# Altium schematic sheet ESP12.SchDoc
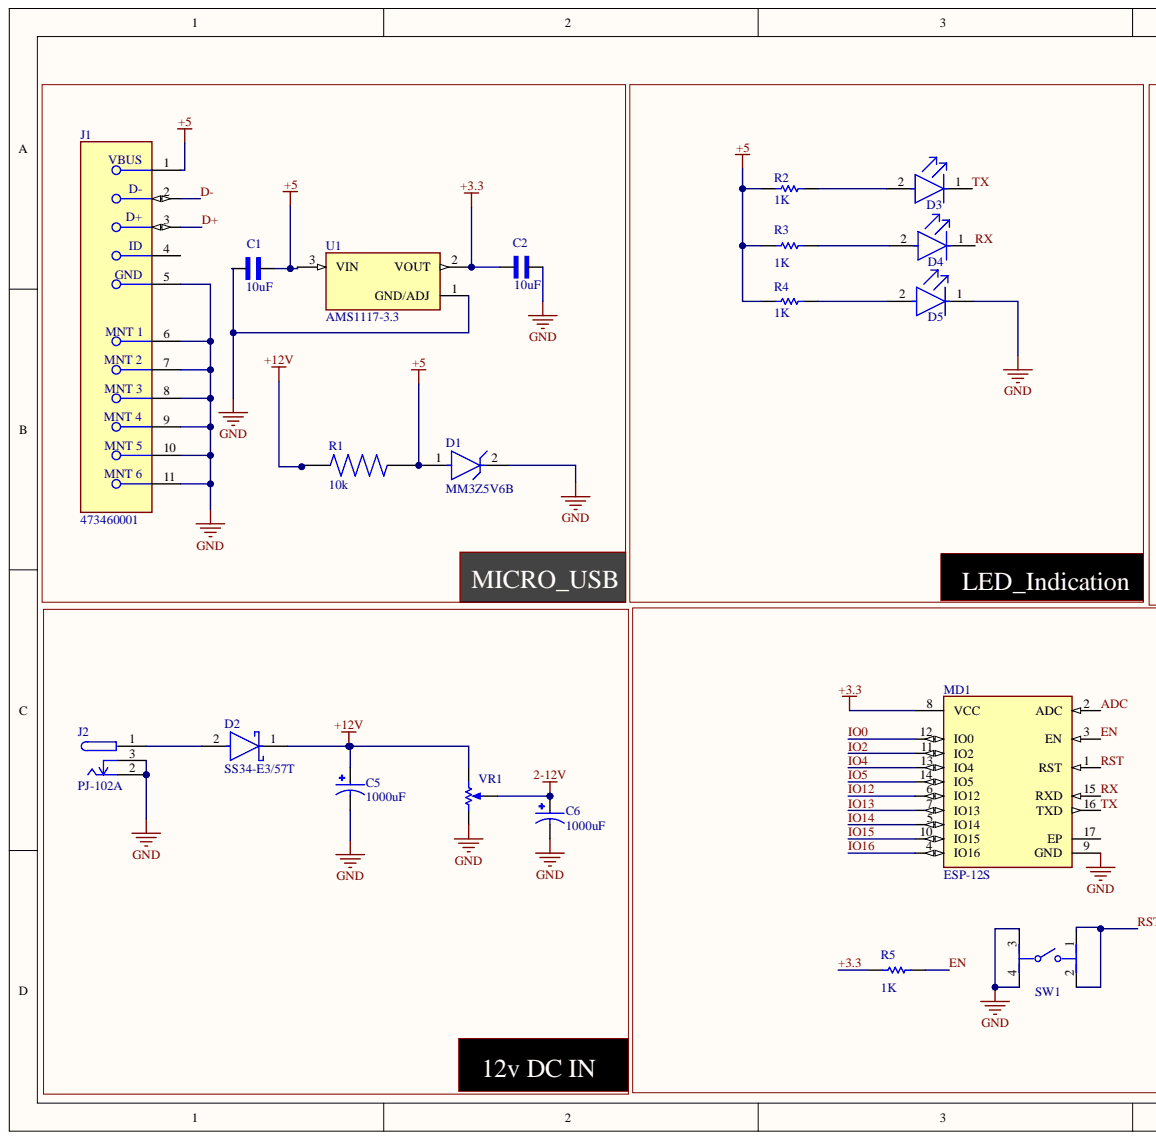
[diagram p0: ESP12.SchDoc, left]
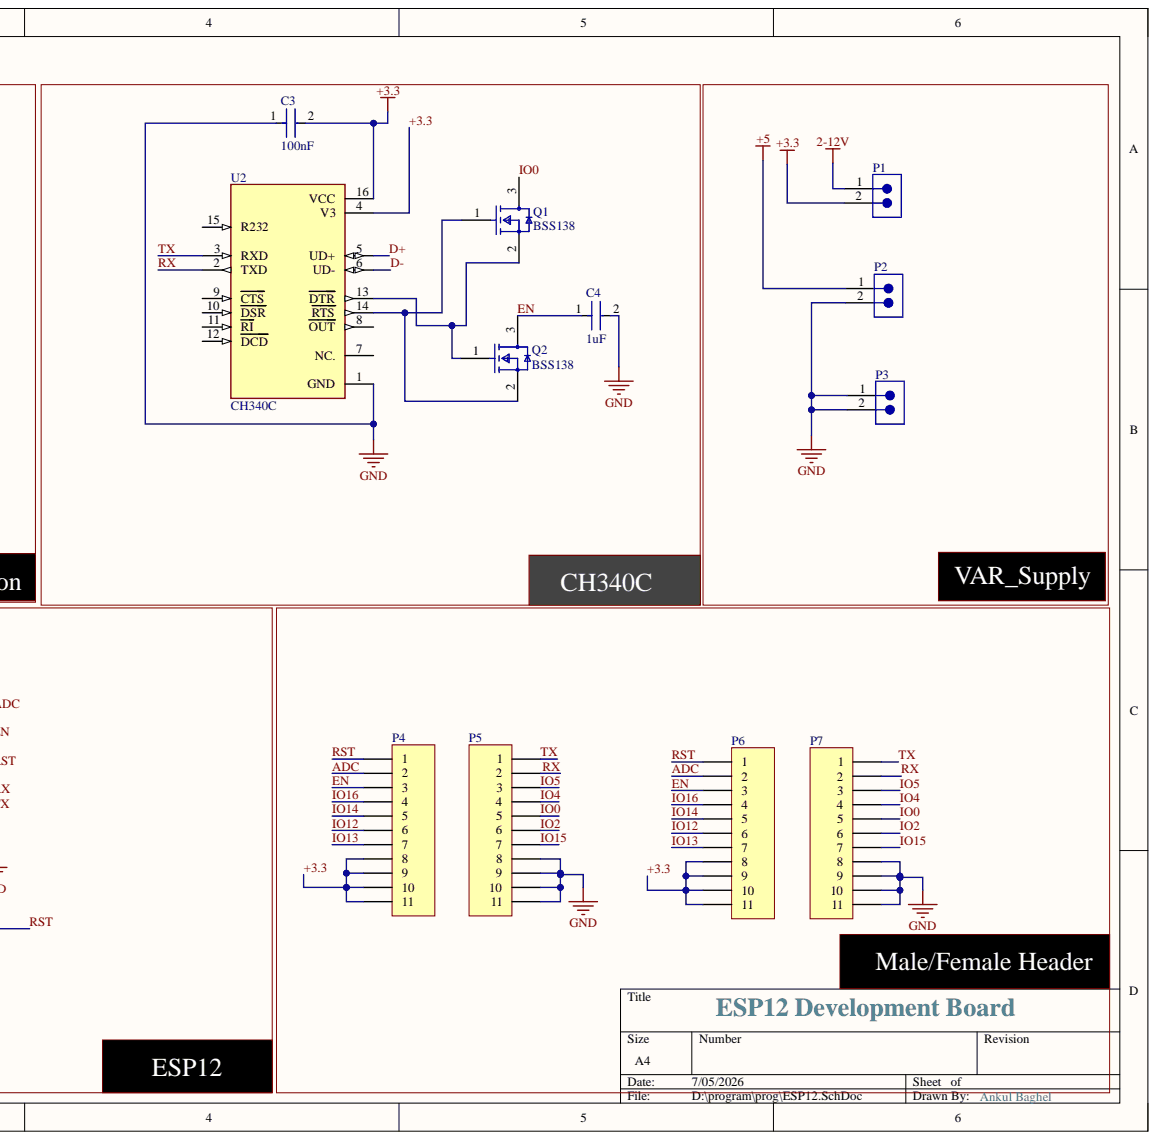
[diagram p1: ESP12.SchDoc, right]

## Parts
C1  10uF  [CAPC2012X145N]
C2  10uF  [CAPC2012X145N]
C3  100nF  [FP-CC0805-0_85-MFG]
C4  1uF  [FP-CC0805-0_85-MFG]
C5  1000uF  [CAPPRD500W60D1000H1600]
C6  1000uF  [CAPPRD500W60D1000H1600]
D1  MM3Z5V6B  [FP-MM3Z5V6B-MFG]
D2  SS34-E3/57T  [FP-95402-MFG]
D3  LTST-C190KRKT  [FP-LTST-C190KRKT-MFG]  (value not drawn)
D4  LTST-C190KGKT  [FP-LTST-C190KGKT-MFG]  (value not drawn)
D5  LTST-C190KRKT  [FP-LTST-C190KRKT-MFG]  (value not drawn)
J1  473460001  [FP-473460001-MFG]
J2  PJ-102A  [FP-PJ-102A-MFG]
MD1  ESP-12S  [FP-ESP-12S-MFG]
P1  61300211121  [61300211121]  (value not drawn)
P2  61300211121  [61300211121]  (value not drawn)
P3  61300211121  [61300211121]  (value not drawn)
P4  Header 11  [HDR1X11]  (value not drawn)
P5  Header 11  [HDR1X11]  (value not drawn)
P6  Header 11  [HDR1X11]  (value not drawn)
P7  Header 11  [HDR1X11]  (value not drawn)
Q1  BSS138  [FP-318-08-IPC_B]
Q2  BSS138  [FP-318-08-IPC_B]
R1  10k  [RESC2012X60N]
R2  1K  [RESC2013X60X35ML10T20]
R3  1K  [RESC2013X60X35ML10T20]
R4  1K  [RESC2013X60X35ML10T20]
R5  1K  [RESC2013X60X35ML10T20]
SW1  PTS645SM43SMTR92LFS  [PTS645SM43SMTR92LFS_MFG]  (value not drawn)
U1  AMS1117-3.3  [SOT229P700X180-4N]
U2  CH340C  [SOIC127P600X180-16N]
VR1  3362P-1-103LF  [BOUR-3362P]  (value not drawn)

## Nets
2-12V: C6.P P1.1 VR1.2
+5: C1.2 D1.1 J1.1 P2.1 R1.2 R2.1 R3.1 R4.1 U1.3
+12V: C5.P D2.1 R1.1 VR1.3
GND: C1.1 C2.2 C3.1 C4.2 C5.N C6.N D1.2 D5.1 J1.5 J1.6 J1.7 J1.8 J1.9 J1.10 J1.11 J2.2 J2.3 MD1.9(GND) P2.2 P3.1 P3.2 P5.8 P5.9 P5.10 P5.11 P7.8 P7.9 P7.10 P7.11 SW1.3 SW1.4 U1.1 U2.1(GND) VR1.1
+3.3: C2.1 C3.2 MD1.8(VCC) P1.2 P4.8 P4.9 P4.10 P4.11 P6.8 P6.9 P6.10 P6.11 R5.1 U1.2 U2.4(V3) U2.16(VCC)
ADC: MD1.2(ADC) P4.2 P6.2
D+: J1.3 U2.5(UD+)
D-: J1.2 U2.6(UD-)
EN: C4.1 MD1.3(EN) P4.3 P6.3 Q2.3 R5.2
IO0: MD1.12(IO0) P5.5 P7.5 Q1.3
IO2: MD1.11(IO2) P5.6 P7.6
IO4: MD1.13(IO4) P5.4 P7.4
IO5: MD1.14(IO5) P5.3 P7.3
IO12: MD1.6(IO12) P4.6 P6.6
IO13: MD1.7(IO13) P4.7 P6.7
IO14: MD1.5(IO14) P4.5 P6.5
IO15: MD1.10(IO15) P5.7 P7.7
IO16: MD1.4(IO16) P4.4 P6.4
RST: MD1.1(RST) P4.1 P6.1 SW1.1 SW1.2
RX: D4.1 MD1.15(RXD) P5.2 P7.2 U2.2(TXD)
TX: D3.1 MD1.16(TXD) P5.1 P7.1 U2.3(RXD)
NetD2_2: D2.2 J2.1
NetD3_2: D3.2 R2.2
NetD4_2: D4.2 R3.2
NetD5_2: D5.2 R4.2
NetQ1_1: Q1.1 Q2.2 U2.14(RTS)
NetQ1_2: Q1.2 Q2.1 U2.13(DTR)

Not connected: J1 4; MD1 17(EP); U2 7(NC.) 8(OUT) 9(CTS) 10(DSR) 11(RI) 12(DCD) 15(R232)

## Library data of the parts
C1 C2 = CC0805KKX5R8BB106: 10 ÂµF Â±10% 25V Ceramic Capacitor X5R 0805 (2012 Metric); MF: Yageo; Check_prices: https://www.snapeda.com/parts/CC0805KKX5R8BB106/Yageo/view-part/?ref=eda; Component Kind: Standard; Library Name: CC0805KKX5R8BB106.IntLib; MP: CC0805KKX5R8BB106; Package: 0805 Yageo; SnapEDA_Link: https://www.snapeda.com/parts/CC0805KKX5R8BB106/Yageo/view-part/?ref=snap
C3 = CMP-2100-03606-3: CAP CER 0.1UF 50V X7R 0805; Manufacturer: Yageo; Manufacturer Part Number: CC0805KRX7R9BB104; Material: Ceramic; Tolerance: 0.1; Automotive: No; Capacitance: 100 nF; Category: Cap; Component Kind: Standard; ComponentLink2Description: Datasheet; ComponentLink2URL: http://www.yageo.com/documents/recent/UPY-GPHC_Y5V_6.3V-to-50V_8.pdf; Device Class L1: Passive Components; Device Class L2: Capacitors; Device Class L3: Ceramic Capacitors; Digikey Description: CAP CER 0.1UF 50V X7R 0805; Footprint Url: http://www.yageo.com/exep/pages/download/literatures/UPY-C_GEN_24.pdf; Height: 0.95mm; Ipc Land Pattern Name: CAPC200125X85; Lead Free: Yes; Library Name: Altium Content Vault; Mouser Description: Multilayer Ceramic Capacitors MLCC - SMD/SMT 100nF 50V X7R 10%; Octopart: https://octopart.com/cc0805krx7r9bb104-yageo-192993; Package: CC0805-0.85; RoHS: TRUE; Temperature: -30°C to +85°C; Temperature Characteristic: X7R; Temperature Range High: +85°C; Temperature Range Low: -30°C; Value: 100 nF; Voltage Rating: 50 V
C4 = CMP-03422-000001-1: CAP CER 1UF 16V X7R 0805; Manufacturer: Yageo; Manufacturer Part Number: CC0805KKX7R7BB105; Material: Ceramic; Tolerance: 0.1; Automotive: No; Capacitance: 1 uF; Category: Cap; Component Kind: Standard; ComponentLink2Description: Datasheet; ComponentLink2URL: http://www.yageo.com/documents/recent/UPY-GPHC_Y5V_6.3V-to-50V_8.pdf; Device Class L1: Passive Components; Device Class L2: Capacitors; Device Class L3: Ceramic Capacitors; Digikey Description: CAP CER 1UF 16V X7R 0805; Footprint Url: http://www.yageo.com/exep/pages/download/literatures/UPY-C_GEN_24.pdf; Height: 0.95mm; Ipc Land Pattern Name: CAPC200125X85; Lead Free: Yes; Library Name: Altium Content Vault; Mouser Description: Multilayer Ceramic Capacitors MLCC - SMD/SMT 1.0uF 16V X7R 10%; Octopart: https://octopart.com/cc0805kkx7r7bb105-yageo-8376555; Package: CC0805-0.85; RoHS: TRUE; Temperature: -30°C to +85°C; Temperature Characteristic: X7R; Temperature Range High: +85°C; Temperature Range Low: -30°C; Value: 1 uF; Voltage Rating: 16 V
C5 C6 = EEUFR1C102B: CAP ALUM 1000UF 20% 16V RADIAL; MF: Panasonic; MP: EEU-FR1C102B; Availability: In Stock; Check_prices: https://www.snapeda.com/parts/EEU-FR1C102B/Panasonic/view-part/2318035/?ref=eda; Component Kind: Standard; Library Name: EEUFR1C102B.IntLib; Package: RADIAL-2 Panasonic; Price: None; Purchase-URL: https://pricing.snapeda.com/search/part/EEU-FR1C102B/?ref=eda; SnapEDA_Link: https://www.snapeda.com/parts/EEU-FR1C102B/Panasonic/view-part/2318035/?ref=snap
D1 = CMP-07164-000010-1: DIODE ZENER 5.6V 200MW SOD323F; Manufacturer: ON Semiconductor; Forward Current: 10mA; Max Junction Temp: +150°C; Max Reverse Leakage Current: 0.9uA; Max Zener Voltage: 5.71V; Reverse Voltage: 2V; Zener Impedance: 37Ω; Automotive: No; Category: Diode; Component Kind: Standard; ComponentLink2Description: Datasheet; ComponentLink2URL: https://www.onsemi.com/pub/Collateral/MM3Z9V1B-D.pdf; Device Class L1: Discrete Semiconductors; Device Class L2: Diodes; Device Class L3: Zener Diodes; Digikey Description: DIODE ZENER 5.6V 200MW SOD323F; Height: 1mm; Lead Free: Yes; Library Name: Altium Content Vault; Manufacturer Part Number: MM3Z5V6B; Mouser Description: Zener Diodes Diode Zener 5.6V 200mW 2%; Nom Zener Voltage: 5.6V; Octopart: https://octopart.com/mm3z5v6b-on+semiconductor-84329898; Package: SOD323F; Power Dissipation: 200mW; RoHS: TRUE; Temperature: to +150°C; Temperature Range High: +150°C
D2 = CMP-02539-000012-1: DIODE SCHOTTKY 40V 3A DO214AB; Manufacturer: Vishay; Max Junction Temp: +150°C; Max Surge Forward Current: 100A; Peak Reverse Current: 0.5mA; Standoff Height: 0mm; Automotive: No; Category: Diode; Component Kind: Standard; ComponentLink2Description: Datasheet; ComponentLink2URL: http://www.vishay.com/docs/88751/ss32.pdf; Device Class L1: Discrete Semiconductors; Device Class L2: Diodes; Device Class L3: Schottky Diodes; Digikey Description: DIODE SCHOTTKY 40V 3A DO214AB; Footprint Url: http://www.vishay.com/docs/95402/smc.pdf; Forward Current: 3A; Height: 2.62mm; Lead Free: Yes; Library Name: Altium Content Vault; Manufacturer Part Number: SS34-E3/57T; Max Repetitive Reverse Voltage: 40V; Mouser Description: Schottky Diodes & Rectifiers 3.0 Amp 40 Volt; Octopart: https://octopart.com/ss34-e3%2F57t-vishay-39552907; Package: 95402; RoHS: TRUE; Temperature: -55°C to +150°C; Temperature Range High: +150°C; Temperature Range Low: -55°C
D3 D5 = CMP-1674-00011-2: LED RED CLEAR CHIP SMD; Dominant Wavelength: 631nm; Forward Current: 30mA; Forward Voltage: 2.4V; Lens Color: Colorless; Lens Style: Diffused; Luminous Intensity: 54mcd; Number of Elements: 1; Power Dissipation: 75mW; Reverse Voltage: 5V; Test Current: 20mA; Viewing Angle: 130°; Wavelength: 639nm; Case Code (Imperial): 0603; Case Code (Metric): 1608; Case/Package: 0603; Color: Red; Component Kind: Standard; Depth: 0.8mm; Height: 0.8mm; Illumination Color: Red; Lead Free: Lead Free; Length: 1.6mm; Lens Transparency: Clear; Library Name: Altium Content Vault; Max Operating Temperature: 85°C; Min Operating Temperature: -55°C; Mount: Surface Mount; Number of LEDs: 1; Number of Pins: 2; Package Quantity: 1; Packaging: Tape and Reel; Power Rating: 75mW; Radiation Hardening: No; Width: 0.8mm
D4 = CMP-2000-05197-2: LED GREEN CLEAR CHIP SMD; Dominant Wavelength: 571nm; Forward Current: 30mA; Forward Voltage: 2V; Lens Color: Colorless; Lens Style: Diffused; Luminous Intensity: 35mcd; Number of Elements: 1; Power Dissipation: 75mW; Reverse Voltage: 5V; Test Current: 20mA; Viewing Angle: 130°; Wavelength: 574nm; Case Code (Imperial): 0603; Case Code (Metric): 1608; Case/Package: 0603; Color: Green; Component Kind: Standard; Depth: 0.8mm; Height: 0.8mm; Illumination Color: Green; Lead Free: Lead Free; Length: 1.6mm; Lens Transparency: Clear; Library Name: Altium Content Vault; Max Operating Temperature: 85°C; Min Operating Temperature: -55°C; Mount: Surface Mount; Number of LEDs: 1; Number of Pins: 2; Package Quantity: 1; Packaging: Tape and Reel; Power Rating: 75mW; Radiation Hardening: No; Width: 0.8mm
J1 = CMP-2000-05246-2: CONN RCPT USB2.0 MICRO B SMD R/A; Manufacturer: Molex; Conn Orientation: Right Angle; Current Rating: 1.8A; Is Female: yes; Number Of Contacts: 5; Pitch: 0.65mm; Shielding: true; Voltage Rating: 30V; Automotive: No; Category: Conn; Component Kind: Standard; ComponentLink2Description: Datasheet; ComponentLink2URL: https://www.molex.com/webdocs/datasheets/pdf/en-us/0473460001_IO_CONNECTORS.pdf; Contact Material: Gold,Nickel; Device Class L1: Connectors; Device Class L2: USB Connectors; Device Class L3: unset; Digikey Description: CONN RCPT USB2.0 MICRO B SMD R/A; Footprint Url: https://www.molex.com/pdm_docs/sd/473460001_sd.pdf; Height: 2.945mm; Lead Free: Yes; Library Name: Altium Content Vault; Manufacturer Part Number: 473460001; Octopart: https://octopart.com/47346-0001-molex-7164928; Package: 0; RoHS: TRUE; Temperature: -30°C to +85°C; Temperature Range High: +85°C; Temperature Range Low: -30°C; Usb Standard: USB 2.0
J2 = CMP-12637-106234071-1: PJ-102A 2.0 x 6.5 mm, 2.5 A, Horizontal, Through Hole, Tapered Pins, Dc Power Jack Connector; Current Rating: 2.5A; Gender: Male; Inside Diameter: 2mm; Insulation Resistance: 50MΩ; Number of Conductors: 2; Number of Contacts: 3; Outside Diameter: 6.5mm; Shielding: No; Voltage Rating: 24V; Color: Black; Component Kind: Standard; Contact Gender: Male; Contact Material: Copper, Nickel, Tin; Contact Plating: Nickel; Diameter: 2.1mm; Flammability Rating: UL94 V-0; Height: 11.2mm; Housing Color: Black; Housing Material: Thermoplastic; Lead Free: Lead Free; Library Name: Altium Content Vault; Lifecycle Status: Production; Max Operating Temperature: 85°C; Min Operating Temperature: -25°C; Mount: Through Hole; NexarPartId: 106234071; Orientation: Horizontal; Packaging: Bulk; RoHS: Compliant; Schedule B: 8536695050, 8536695050; Termination: Through Hole; Voltage Rating (DC): 24V
MD1 = CMP-204316-000002-1: RX TXRX MOD WIFI PCB TRACE SMD; Data Rate: 54Mbps; Frequency: 2.484GHz; Interface: I2C; Sensitivity: -90dBm; Component Kind: Standard; Library Name: Altium Content Vault; Series: -
P1-P3 = CMP-1712-00002-7: THT Vertical Pin Header WR-PHD, Pitch 2.54 mm, Single Row, 2 pins; Connector Type: Male Pin; Contact Resistance: 20mΩ; Current Rating: 3A; Gender: Male; Number of Contacts: 2; Operating Supply Voltage: 250V; Pitch: 2.54mm; Color: Black; Component Kind: Standard; Contact Gender: Male; Contact Material: Gold; Contact Mating Length: 5.9944mm; Contact Plating: Gold; Height: 8.94mm; Housing Material: PBT; Lead Free: Lead Free; Lead Pitch: 2.54mm; Library Name: Altium Content Vault; Max Operating Temperature: 105°C; Min Operating Temperature: -40°C; Mount: Through Hole; Number of Pins: 2; Number of Positions: 2; Number of Rows: 1; Orientation: Vertical; Packaging: Bulk; REACH SVHC: No; RoHS: Compliant; Termination: Through Hole; Voltage Rating: 250V
P4-P7 = Header 11: Header, 11-Pin; Component Kind: Standard; LatestRevisionDate: 17-Jul-2002; LatestRevisionNote: Re-released for DXP Platform.; Library Name: Miscellaneous Connectors.IntLib; Signal Integrity: Connector; Publisher: Altium Limited
Q1 Q2 = CMP-07173-000101-1: MOSFET N-CH 50V 220MA SOT-23; Drain to Source Resistance: 3.5R; Element Configuration: Single; Fall Time: 9ns; Gate to Source Voltage (Vgs): 20V; Input Capacitance: 27pF; Max Power Dissipation: 360mW; Nominal Vgs: 1.3V; Number of Elements: 1; On-State Resistance: 6R; Turn-Off Delay Time: 20ns; Turn-On Delay Time: 2.5ns; Case/Package: SOT-23; Component Kind: Standard; Contact Plating: Tin; Continuous Drain Current (ID): 220mA; Current Rating: 220mA; Drain to Source Breakdown Voltage: 50V; Drain to Source Voltage (Vdss): 50V; Dual Supply Voltage: 50V; Height: 0.93mm; Lead Free: Lead Free; Length: 2.92mm; Library Name: Altium Content Vault; Max Operating Temperature: 150°C; Min Operating Temperature: -55°C; Mount: Surface Mount; Number of Pins: 3; Package Quantity: 1; Packaging: Tape and Reel; Power Dissipation: 360mW; Radiation Hardening: No; Rds On Max: 3.5R; REACH SVHC: No SVHC; Rise Time: 9ns; Termination: SMD/SMT; Threshold Voltage: 1.3V; Voltage Rating (DC): 50V; Weight: 0.03g; Width: 1.3mm
R1 = RC0805JR-0710KL: RESISTOR THICK FILM 5% 0.125W; MF: {'manufacturer': u'YAGEO'}; Availability: Unavailable; Component Kind: Standard; Library Name: RC0805JR-0710KL.IntLib; MP: RC0805JR-0710KL; Package: None; Price: None
R2-R5 = CMP-2100-03671-1: Chip Resistor, 1 KOhm, +/- 1%, 125 mW, -55 to 155 degC, 0805 (2012 Metric), RoHS, Tape and Reel; Manufacturer: Yageo; Max Power Dissipation: 125mW; Resistance: 1KOhm; Temperature Coefficient: 100ppm/degreesC; Voltage Rating: 150V; Case Code (Imperial): 0805; Case Code (Metric): 2012; Case/Package: 0805; Component Kind: Standard; Composition: ThickFilm; Datasheet URL: http://www.yageo.com/NewPortal/yageodocoutput?fileName=/pdf/R-Chip/PYu-RC_Group_51_RoHS_L_5.pdf; Datasheet Version: Rev. 5, 10/2016; Depth: 1.25mm; Height: 0.024inch; Lead Free: LeadFree; Length: 0.079inch; Library Name: Altium Content Vault; Manufacturer URL: http://www.yageo.com/; Max Operating Temperature: 155degC; Military Standard: Not; Min Operating Temperature: -55degC; Number of Pins: 2; Number of Terminations: 2; Package Description: 2-Pin Surface Mounted Device, Body 2 x 1.25 mm; Package Quantity: 1; Package Reference: 0805; Packaging: TapeandReel; Power Rating: 125mW; REACH SVHC: Unknown; RoHSCompliant: true; Termination: SMD/SMT; Tolerance: 1%; Voltage Rating (DC): 150V; Width: 0.049inch
SW1 = CMP-2000-05160-3: Switch Tactile N.O SPST Round Button Gull Wing 0.05A 12VDC 100000Cycle 1.57N SMD T/R; Actuator Length: 850µm; Actuator Material: Nylon, Polyamide; Contact Current Rating: 50mA; Contact Resistance: 100mΩ; Electrical Life: 100kcycles; Illumination Type: Illuminated, Non-Illuminated; Insulation Resistance: 100GΩ; Max Voltage Rating (DC): 12V; Number of Positions: 1; Switch Function: Off-Mom; Case/Package: SMD/SMT; China RoHS: Compliant; Color: Black; Component Kind: Standard; ComponentLink1Description: Manufacturer's Website; ComponentLink1URL: http://www.ck-components.com/; ComponentLink2Description: Datasheet URL; ComponentLink2URL: https://www.ckswitches.com/media/1471/pts645.pdf; Contact Material: Silver; Contact Plating: Silver; Current Rating: 50mA; Depth: 9mm; Height: 3.45mm; HelpURL: https://www.ckswitches.com/media/1471/pts645.pdf; Lead Free: Lead Free; Length: 6mm; Library Name: Altium Content Vault; Life (Cycles): 100kcycles; Lifecycle Status: Production; Manufacturer Package Identifier: PTS645SM43SMTR92LFS; Max Current Rating: 50mA; Max Operating Temperature: 60°C; Mechanical Life: 100kcycles; Min Operating Temperature: -20°C; Mount: Surface Mount; Operating Force: 1.57N; Orientation: Straight; Packaging: Cut Tape (CT); REACH SVHC: Yes; RoHS: Compliant; Termination: Solder; Throw Configuration: SPST; Voltage Rating (DC): 12V
U1 = AMS1117-3.3: Sot223/Pkg 1-Amp 3.3-Volt Low Drop Out Voltage Regulatator; MF: Advanced Monolithic Systems; Check_prices: https://www.snapeda.com/parts/AMS1117-3.3/Advanced+Monolithic+Systems/view-part/?ref=eda; Component Kind: Standard; Library Name: AMS1117-3.3.IntLib; MP: AMS1117-3.3; Package: SOT-223 Seeed Technology; SnapEDA_Link: https://www.snapeda.com/parts/AMS1117-3.3/Advanced+Monolithic+Systems/view-part/?ref=snap
U2 = CH340C: USB to serial chip CH340; MF: WCH; Availability: Not in stock; Check_prices: https://www.snapeda.com/parts/CH340C/WCH/view-part/?ref=eda; Component Kind: Standard; Library Name: CH340C.IntLib; MP: CH340C; Package: None; Price: None; SnapEDA_Link: https://www.snapeda.com/parts/CH340C/WCH/view-part/?ref=snap
VR1 = CMP-1747-00004-1: Square Trimpot(R) Trimming Potentiometer, 10 KOhm, 300 V, -55 to 125 degC, 3-Pin THD, RoHS, Tube; Power Rating: 1 W; Resistance: 50 mohm; Tolerance: 1%; Voltage Rating: 220 mV; Case Code (Imperial): 0612; Case Code (Metric): 1632; Case/Package: 1206; Component Kind: Standard; ComponentLink1Description: Manufacturer URL; ComponentLink1URL: http://www.bourns.com/; ComponentLink2Description: Datasheet; ComponentLink2URL: http://www.bourns.com/docs/Product-Datasheets/3362.pdf; DatasheetVersion: May-16; Height: 0.6 mm; Length: 3.2 mm; Library Name: Altium Content Vault; Max Operating Temperature: 125degC; Min Operating Temperature: -25degC; Mount: Surface Mount; Package Quantity: 5000; PackageDescription: 3-Pin Through Hole Device, Body 6.6 x 6.99 mm, Pitch 2.54 mm; PackageReference: 3326P; Packaging: Tape and Reel; Pins: 2; REACH SVHC: No SVHC; RoHSCompliant: True; Width: 1.6 mm
